# Altium schematic sheet power.SchDoc(power)
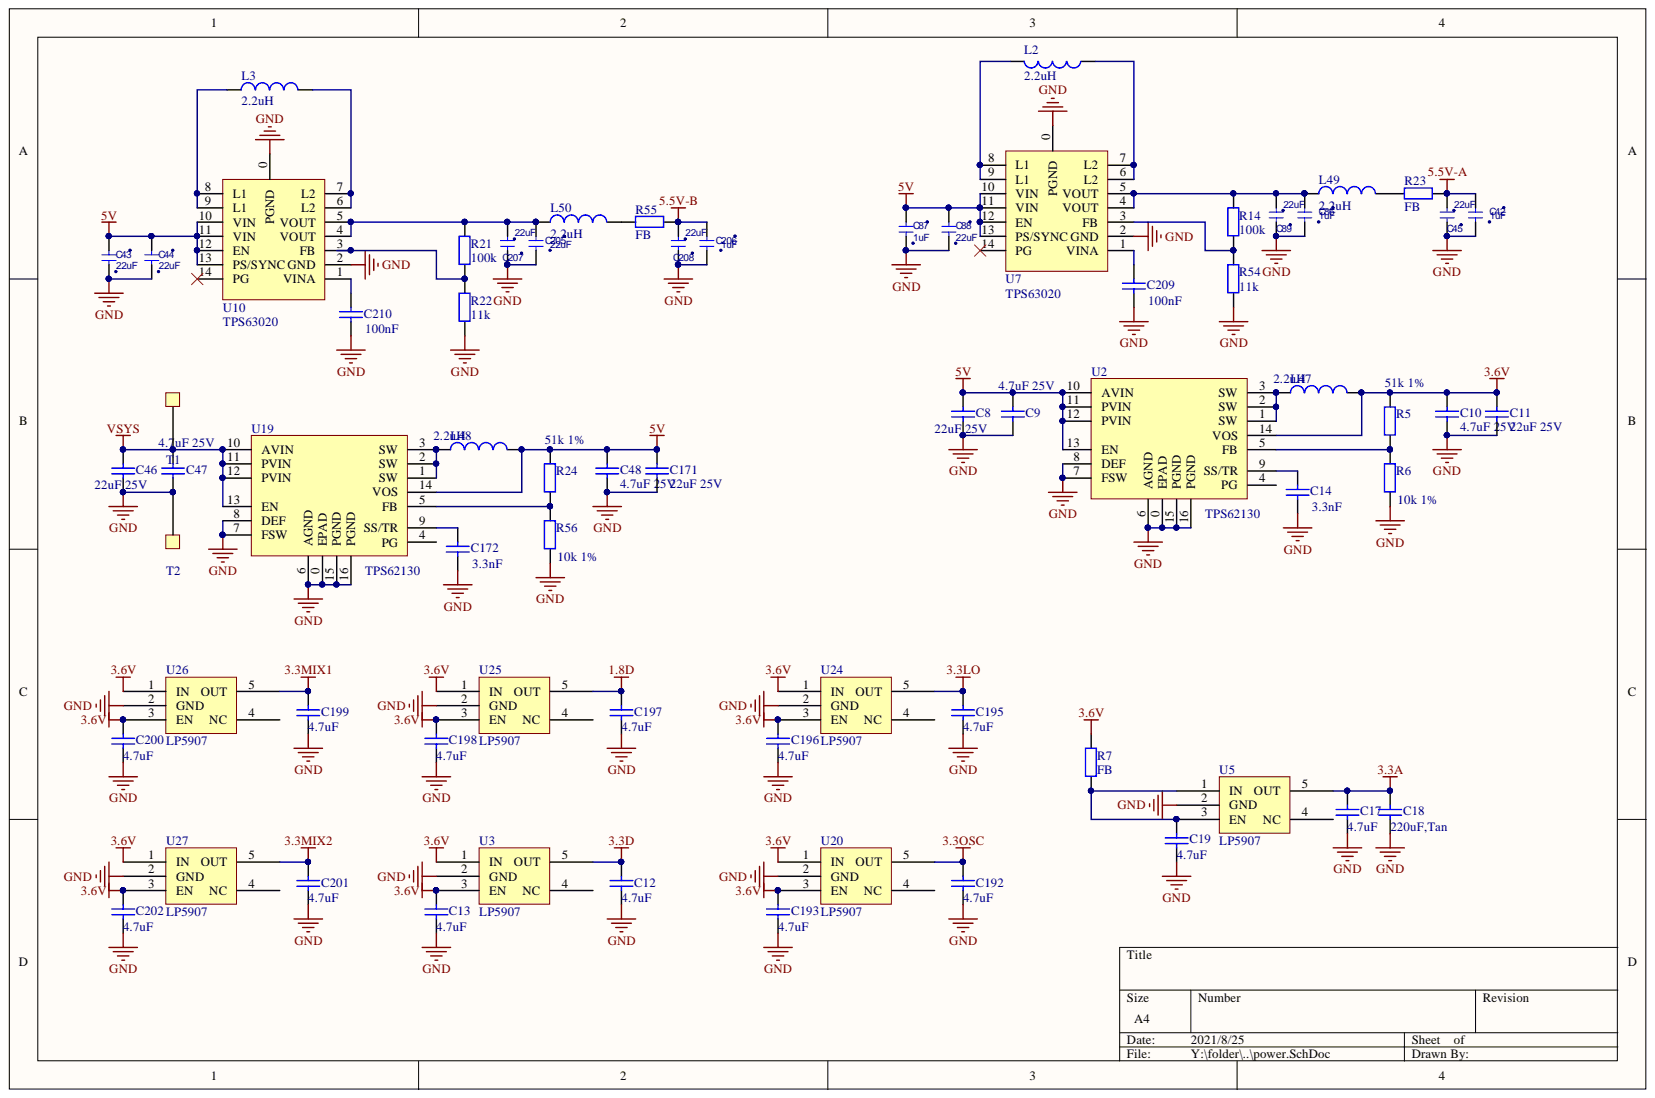
[diagram p0: power.SchDoc]

## Parts
C8  value 22uF 25V  [0805]  (hidden comment: Cap)
C9  value 4.7uF 25V  [0603]  (hidden comment: Cap)
C10  value 4.7uF 25V  [0603]  (hidden comment: Cap)
C11  value 22uF 25V  [0805]  (hidden comment: Cap)
C12  4.7uF  [0603L]
C13  4.7uF  [0603L]
C14  3.3nF  [0402]
C17  4.7uF  [0603L]
C18  220uF,Tan  [1210]
C19  4.7uF  [0603L]
C42  1uF  [0805]
C43  22uF  [0805]
C44  22uF  [0805]
C45  22uF  [0805]
C46  value 22uF 25V  [0805]  (hidden comment: Cap)
C47  value 4.7uF 25V  [0603]  (hidden comment: Cap)
C48  value 4.7uF 25V  [0603]  (hidden comment: Cap)
C86  1uF  [0805]
C87  1uF  [0805]
C88  22uF  [0805]
C89  22uF  [0805]
C171  value 22uF 25V  [0805]  (hidden comment: Cap)
C172  3.3nF  [0402]
C192  4.7uF  [0603L]
C193  4.7uF  [0603L]
C195  4.7uF  [0603L]
C196  4.7uF  [0603L]
C197  4.7uF  [0603L]
C198  4.7uF  [0603L]
C199  4.7uF  [0603L]
C200  4.7uF  [0603L]
C201  4.7uF  [0603L]
C202  4.7uF  [0603L]
C205  22uF  [0805]
C206  1uF  [0805]
C207  22uF  [0805]
C208  22uF  [0805]
C209  100nF  [0402]
C210  100nF  [0402]
L2  2.2uH  [L4015]
L3  2.2uH  [L4015]
L47  2.2uH  [L4015]
L48  2.2uH  [L4015]
L49  2.2uH  [L4015]
L50  2.2uH  [L4015]
R5  51k 1%  [0402]
R6  10k 1%  [0402]
R7  FB  [0603L]
R14  100k  [0402]
R21  100k  [0402]
R22  11k  [0402]
R23  FB  [0603L]
R24  51k 1%  [0402]
R54  11k  [0402]
R55  FB  [0603L]
R56  10k 1%  [0402]
T1  TestPad  [TestPad]  (value not drawn)
T2  TestPad  [TestPad]  (value not drawn)
U2  TPS62130  [TPS62130]
U3  LP5907  [SOT23-5]
U5  LP5907  [SOT23-5]
U7  TPS63020  [TPS63020]
U10  TPS63020  [TPS63020]
U19  TPS62130  [TPS62130]
U20  LP5907  [SOT23-5]
U24  LP5907  [SOT23-5]
U25  LP5907  [SOT23-5]
U26  LP5907  [SOT23-5]
U27  LP5907  [SOT23-5]

## Nets
1.8D: C197.2 U25.5(OUT)
3.3A: C17.2 C18.2 U5.5(OUT)
3.3D: C12.2 U3.5(OUT)
3.3LO: C195.2 U24.5(OUT)
3.3MIX1: C199.2 U26.5(OUT)
3.3MIX2: C201.2 U27.5(OUT)
3.3OSC: C192.2 U20.5(OUT)
3.6V: C10.2 C11.2 C13.2 C193.2 C196.2 C198.2 C200.2 C202.2 L47.2 R5.1 R7.2 U2.14(VOS) U3.1(IN) U3.3(EN) U20.1(IN) U20.3(EN) U24.1(IN) U24.3(EN) U25.1(IN) U25.3(EN) U26.1(IN) U26.3(EN) U27.1(IN) U27.3(EN)
5.5V-A: C42.1 C45.1 R23.1
5.5V-B: C206.1 C208.1 R55.1
5V: C8.1 C9.1 C43.1 C44.1 C48.2 C87.1 C88.1 C171.2 L48.2 R24.1 U2.10(AVIN) U2.11(PVIN) U2.12(PVIN) U2.13(EN) U7.10(VIN) U7.11(VIN) U7.12(EN) U7.13(PS/SYNC) U10.10(VIN) U10.11(VIN) U10.12(EN) U10.13(PS/SYNC) U19.14(VOS)
GND: C8.2 C9.2 C10.1 C11.1 C12.1 C13.1 C14.2 C17.1 C18.1 C19.1 C42.2 C43.2 C44.2 C45.2 C46.2 C47.2 C48.1 C86.2 C87.2 C88.2 C89.2 C171.1 C172.2 C192.1 C193.1 C195.1 C196.1 C197.1 C198.1 C199.1 C200.1 C201.1 C202.1 C205.2 C206.2 C207.2 C208.2 C209.2 C210.2 R6.2 R22.2 R54.2 R56.2 T2.1 U2.0(EPAD) U2.6(AGND) U2.7(FSW) U2.8(DEF) U2.15(PGND) U2.16(PGND) U3.2(GND) U5.2(GND) U7.0(PGND) U7.2(GND) U10.0(PGND) U10.2(GND) U19.0(EPAD) U19.6(AGND) U19.7(FSW) U19.8(DEF) U19.15(PGND) U19.16(PGND) U20.2(GND) U24.2(GND) U25.2(GND) U26.2(GND) U27.2(GND)
VSYS: C46.1 C47.1 T1.1 U19.10(AVIN) U19.11(PVIN) U19.12(PVIN) U19.13(EN)
NetC14_1: C14.1 U2.9(SS/TR)
NetC19_2: C19.2 R7.1 U5.1(IN) U5.3(EN)
NetC86_1: C86.1 C89.1 L49.1 R14.1 U7.4(VOUT) U7.5(VOUT)
NetC172_1: C172.1 U19.9(SS/TR)
NetC205_1: C205.1 C207.1 L50.1 R21.1 U10.4(VOUT) U10.5(VOUT)
NetC209_1: C209.1 U7.1(VINA)
NetC210_1: C210.1 U10.1(VINA)
NetL2_1: L2.1 U7.6(L2) U7.7(L2)
NetL2_2: L2.2 U7.8(L1) U7.9(L1)
NetL3_1: L3.1 U10.8(L1) U10.9(L1)
NetL3_2: L3.2 U10.6(L2) U10.7(L2)
NetL47_1: L47.1 U2.1(SW) U2.2(SW) U2.3(SW)
NetL48_1: L48.1 U19.1(SW) U19.2(SW) U19.3(SW)
NetL49_2: L49.2 R23.2
NetL50_2: L50.2 R55.2
NetR5_2: R5.2 R6.1 U2.5(FB)
NetR14_2: R14.2 R54.1 U7.3(FB)
NetR21_2: R21.2 R22.1 U10.3(FB)
NetR24_2: R24.2 R56.1 U19.5(FB)

Not connected: U2 4(PG); U3 4(NC); U5 4(NC); U7 14(PG); U10 14(PG); U19 4(PG); U20 4(NC); U24 4(NC); U25 4(NC); U26 4(NC); U27 4(NC)

## Library data of the parts
C8 C9 C11-C14 C17-C19 C46 C47 C171 C172 C192 C193 C195-C202 C209 C210 = Cap: Capacitor; Simulation: CAP; Signal Integrity: Cap; Published: 8-Jun-2000; PackageReference: RAD-0.3; PackageDescription: Radial Cap, Thru-Hole; 2 Leads; 0.3 in Pin Spacing; Library Name: RTC6705.SchLib; LatestRevisionNote: Re-released for DXP Platform.; LatestRevisionDate: 17-Jul-2002; Component Kind: Standard; Publisher: Altium Limited
C10 C48 = Cap: Capacitor; Value: 4.7uF 25V; Simulation: CAP; Signal Integrity: Cap; Published: 8-Jun-2000; PackageReference: RAD-0.3; PackageDescription: Radial Cap, Thru-Hole; 2 Leads; 0.3 in Pin Spacing; Library Name: RTC6705.SchLib; LatestRevisionNote: Re-released for DXP Platform.; LatestRevisionDate: 17-Jul-2002; Component Kind: Standard; Publisher: Altium Limited
C42-C45 C86-C89 C205-C208 = C: Value: 10uF/16V; Source_Path: C:\USERS\EULER-SJ\DESKTOP\CHEN-PI2\LIBRARY1.OLB; PSpiceTemplate: C^@REFDES %1 %2 ?TOLERANCE|C^@REFDES| @VALUE ?IC/IC=@IC/ ?TOLERANCE|\n.model C^@REFDES CAP C=1 DEV=@TOLERANCE%|; PCB DECAL: C0805; Component Kind: Standard
L2 L3 L47-L50 = Inductor: Code_IEC: 1005; Simulation: INDUCTOR; Signal Integrity: Inductor; Published: 8-Jun-2000; PackageReference: 0402-A; PackageDescription: Chip Inductor; Library Name: Miscellaneous Devices.IntLib; LatestRevisionNote: IPC-7351 Footprint Added.; LatestRevisionDate: 29-May-2009; Description: Inductor; Component Kind: Standard; Code_JEITA: 0402; Publisher: Altium Limited
R5 R6 R14 R21 R22 R24 R54 R56 = Res2: Resistor; Simulation: RESISTOR; Signal Integrity: Res; Published: 8-Jun-2000; PackageReference: AXIAL-0.4; PackageDescription: Axial Device, Thru-Hole; 2 Leads; 0.4 in Pin Spacing; Library Name: RTC6705.SchLib; LatestRevisionNote: Re-released for DXP Platform.; LatestRevisionDate: 17-Jul-2002; Component Kind: Standard; Publisher: Altium Limited
R7 R23 R55 = Res2: Resistor; Value: 0Ohm; Simulation: RESISTOR; Signal Integrity: Res; Published: 8-Jun-2000; PackageReference: AXIAL-0.4; PackageDescription: Axial Device, Thru-Hole; 2 Leads; 0.4 in Pin Spacing; Library Name: Miscellaneous Devices.IntLib; LatestRevisionNote: Re-released for DXP Platform.; LatestRevisionDate: 17-Jul-2002; Component Kind: Standard; Publisher: Altium Limited
T1 T2 = TestPad: Library Name: sch.SchLib; Component Kind: Standard
U2 U19 = TPS62130: Library Name: sch.SchLib; Component Kind: Standard
U3 U5 U20 U24-U27 = LP5907: Library Name: RTC6705.SchLib; Component Kind: Standard
U7 U10 = TPS63020: Library Name: sch.SchLib; Component Kind: Standard
